# Altium schematic sheet Main.SchDoc
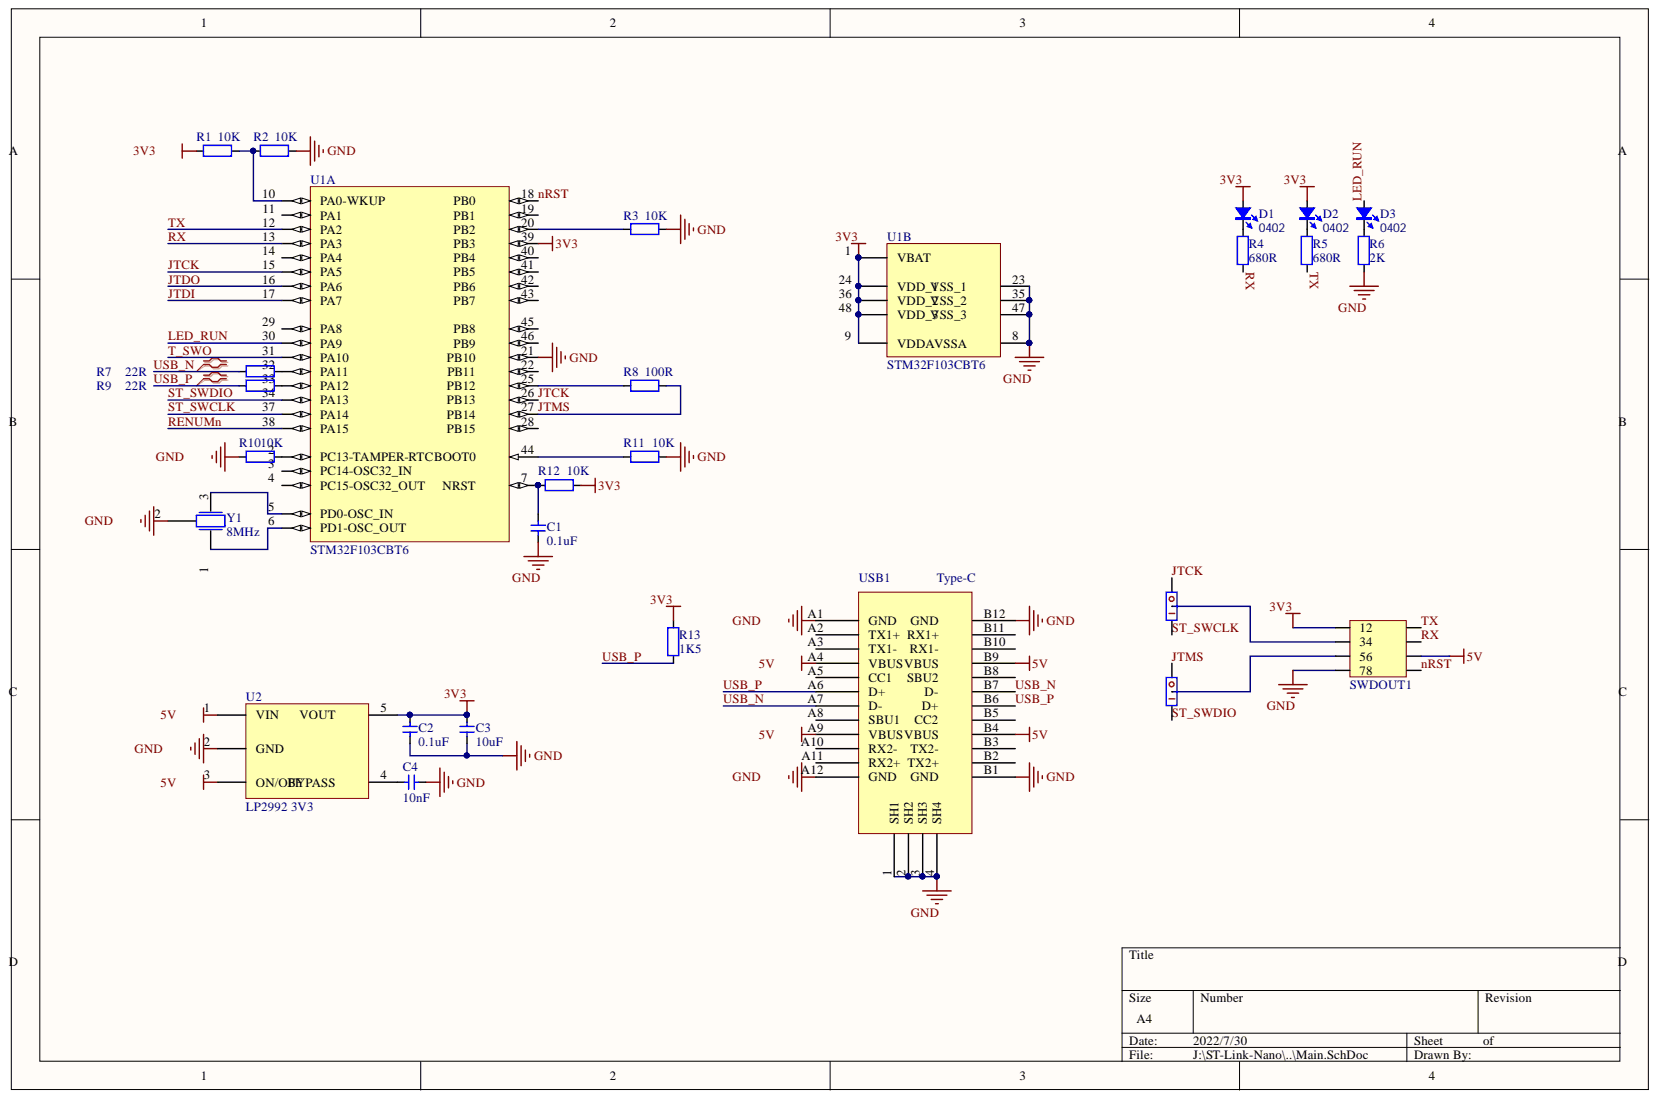
[diagram p0: Main.SchDoc]

## Parts
C1  0.1uF  [CAP_0402]
C2  0.1uF  [CAP_0402]
C3  10uF  [CAP_0402]
C4  10nF  [CAP_0402]
D1  Type: 0402  [LED_0402_BLUE]  (hidden comment: color?)
D2  Type: 0402  [LED_0402_YELLOW]  (hidden comment: color?)
D3  Type: 0402  [LED_0402_GREEN]  (hidden comment: color?)
J1  选择跳线  [jumper-3]  (value not drawn)
J2  选择跳线  [jumper-3]  (value not drawn)
R1  10K  [RES_0402]
R2  10K  [RES_0402]
R3  10K  [RES_0402]
R4  680R  [RES_0402]
R5  680R  [RES_0402]
R6  2K  [RES_0402]
R7  22R  [RES_0402]
R8  100R  [RES_0402]
R9  22R  [RES_0402]
R10  10K  [RES_0402]  (value not drawn)
R11  10K  [RES_0402]
R12  10K  [RES_0402]
R13  1K5  [RES_0402]
SWDOUT1  HDR2x4_2.54  [HDR2X4_2.54_180H]  (value not drawn)
U1  STM32F103CBT6  [LQFP-48]  (parts A,B)
U2  LP2992 3V3  [SOT23-5]
USB1  Type-C  [Type-C-TOP]
Y1  8MHz  [晶振-3P]

## Nets
3V3: C2.2 C3.2 D1.1 D2.1 R1.1 R12.2 R13.2 SWDOUT1.1 U1.1(VBAT) U1.9 U1.24(VDD_1) U1.36(VDD_2) U1.39 U1.48(VDD_3) U2.5
5V: SWDOUT1.6 U2.1(VIN) U2.3(ON/OFF) USB1.A4 USB1.A9 USB1.B4 USB1.B9
GND: C1.1 C2.1 C3.1 C4.1 R2.2 R3.2 R6.1 R10.1 R11.2 SWDOUT1.7 U1.8 U1.21 U1.23 U1.35 U1.47 U2.2(GND) USB1.1(SH1) USB1.2(SH2) USB1.3(SH3) USB1.4(SH4) USB1.A1(GND) USB1.A12(GND) USB1.B1 USB1.B12 Y1.2
JTCK: J1.1 U1.15(PA5) U1.26
JTDI: U1.17(PA7)
JTDO: U1.16(PA6)
JTMS: J2.1 R8.2 U1.27
LED_RUN: D3.1 U1.30(PA9)
NRST: SWDOUT1.8 U1.18
RENUMN: U1.38(PA15)
RX: R4.1 SWDOUT1.4 U1.13(PA3)
ST_SWCLK: J1.3 U1.37(PA14)
ST_SWDIO: J2.3 U1.34(PA13)
T_SWO: U1.31(PA10)
TX: R5.1 SWDOUT1.2 U1.12(PA2)
USB_N: R7.1 USB1.A7(D-) USB1.B7
USB_P: R9.1 R13.1 USB1.A6(D+) USB1.B6
NetC1_2: C1.2 R12.1 U1.7
NetC4_2: C4.2 U2.4
NetD1_2: D1.2 R4.2
NetD2_2: D2.2 R5.2
NetD3_2: D3.2 R6.2
NetJ1_2: J1.2 SWDOUT1.3
NetJ2_2: J2.2 SWDOUT1.5
NetR1_2: R1.2 R2.1 U1.10(PA0-WKUP)
NetR3_1: R3.1 U1.20
NetR7_2: R7.2 U1.32(PA11)
NetR8_1: R8.1 U1.25
NetR9_2: R9.2 U1.33(PA12)
NetR10_2: R10.2 U1.2
NetR11_1: R11.1 U1.44
NetU1_5: U1.5(PD0-OSC_IN) Y1.3
NetU1_6: U1.6(PD1-OSC_OUT) Y1.1

Not connected: U1 3(PC14-OSC32_IN) 4(PC15-OSC32_OUT) 11(PA1) 14(PA4) 19 22 28 29(PA8) 40 41 42 43 45 46; USB1 A2(TX1+) A3(TX1-) A5(CC1) A8(SBU1) A10(RX2-) A11(RX2+) B2 B3 B5 B8 B10 B11

## Library data of the parts
C1-C4 = 电容-0402: Capacitor; Value: 0.1u; Component Kind: Standard; Library Name: MyPcbLib.IntLib
D1-D3 = LED_0402: LED; Component Kind: Standard; Library Name: KitSprout.IntLib; Value: color?
J1 J2 = 选择跳线: Resistor; Component Kind: Standard; LatestRevisionDate: 17-Jul-2002; LatestRevisionNote: Re-released for DXP Platform.; Library Name: MyPcbLib.IntLib; PackageDescription: Axial Device, Thru-Hole; 2 Leads; 0.4 in Pin Spacing; PackageReference: AXIAL-0.4; Published: 8-Jun-2000; Publisher: Altium Limited
R1-R6 R8 R10-R13 = 电阻-0402: Resistor; Value: 22R; Component Kind: Standard; Library Name: MyPcbLib.IntLib
R7 R9 = 电阻-0402: Resistor; Component Kind: Standard; Library Name: MyPcbLib.IntLib; Value: 22R
SWDOUT1 = HDR2x4_2.54: Header; Component Kind: Standard; Library Name: MyPcbLib.IntLib
U1 = STM32F103CBT6: ARM Cortex-M3 32-bit MCU, 128 KB Flash, 20 KB Internal RAM, 37 I/Os, 48-pin LQFP, -40 to 85 degC, Tray; A/D Converter: 2x12-bit (10 Channel); FLASH Size (Prog) (kB): 128; I/Os (High Current): 37; Internal RAM Size (kB): 20; Operating Frequency(F) (Processor speed) (MHz): 72; Supply Voltage(Vcc) min (V): 2; Component Kind: Standard; ComponentLink1Description: Manufacturer URL; ComponentLink1URL: http://www.st.com/web/en/home.html; ComponentLink2Description: Datasheet; ComponentLink2URL: http://www.st.com/st-web-ui/static/active/en/resource/technical/document/datasheet/CD00161566.pdf; ComponentLink3Description: Package Specification; ComponentLink3URL: http://www.st.com/st-web-ui/static/active/en/resource/technical/document/datasheet/DM00058181.pdf; Core: ARM Cortex-M3; DatasheetVersion: Rev. 16; Library Name: STMicroelectronics STM32 F1.IntLib; Mounting Technology: Surface Mount; PackageDescription: 48-Pin Low Profile Quad Flat Package, 7 x 7 mm Body, 0.5 mm Pitch; PackageReference: LQFP48; PackageVersion: Rev. 6, 06/2013; Packing: Tray; PartNumber: STM32F103CBT6; Supplier 1: Digi-Key; Supplier 2: Farnell; Supplier 3: Newark; Supplier 4: Newark; Supplier 5: Mouser; Supplier Part Number 1: 497-6288-ND; Supplier Part Number 2: 1606327; Supplier Part Number 3: 11N8296; Supplier Part Number 4: 57P1214; Supplier Part Number 5: 511-STM32F103CBT6
U2 = LP2992: LDO; Component Kind: Standard; Library Name: MyPcbLib.IntLib
USB1 = USB-Type-C-TOP: Component Kind: Standard; Library Name: MyPcbLib.IntLib
Y1 = 晶振-3Pin: 村田贴片; Component Kind: Standard; Library Name: MyPcbLib.IntLib
